# Altium schematic sheet [12] - ANALOG SIGNAL.SchDoc("[12] - ANALOG SIGNAL")
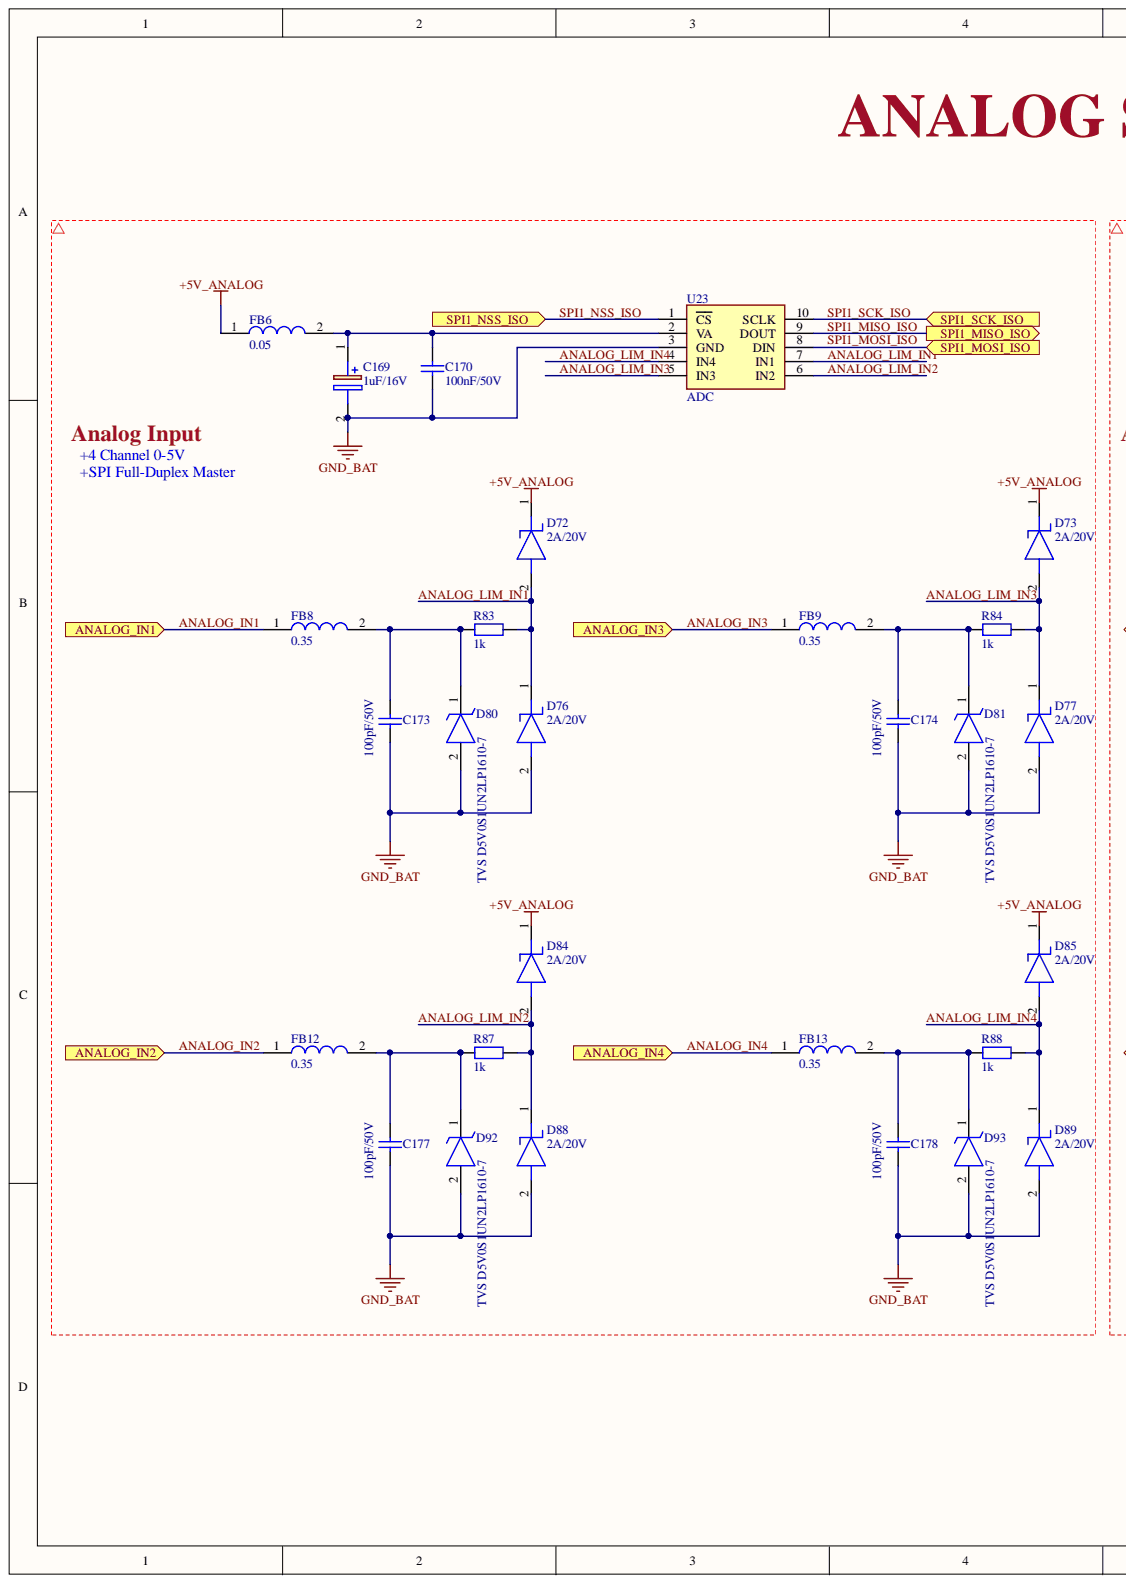
[diagram p0: [12] - ANALOG SIGNAL.SchDoc, left]
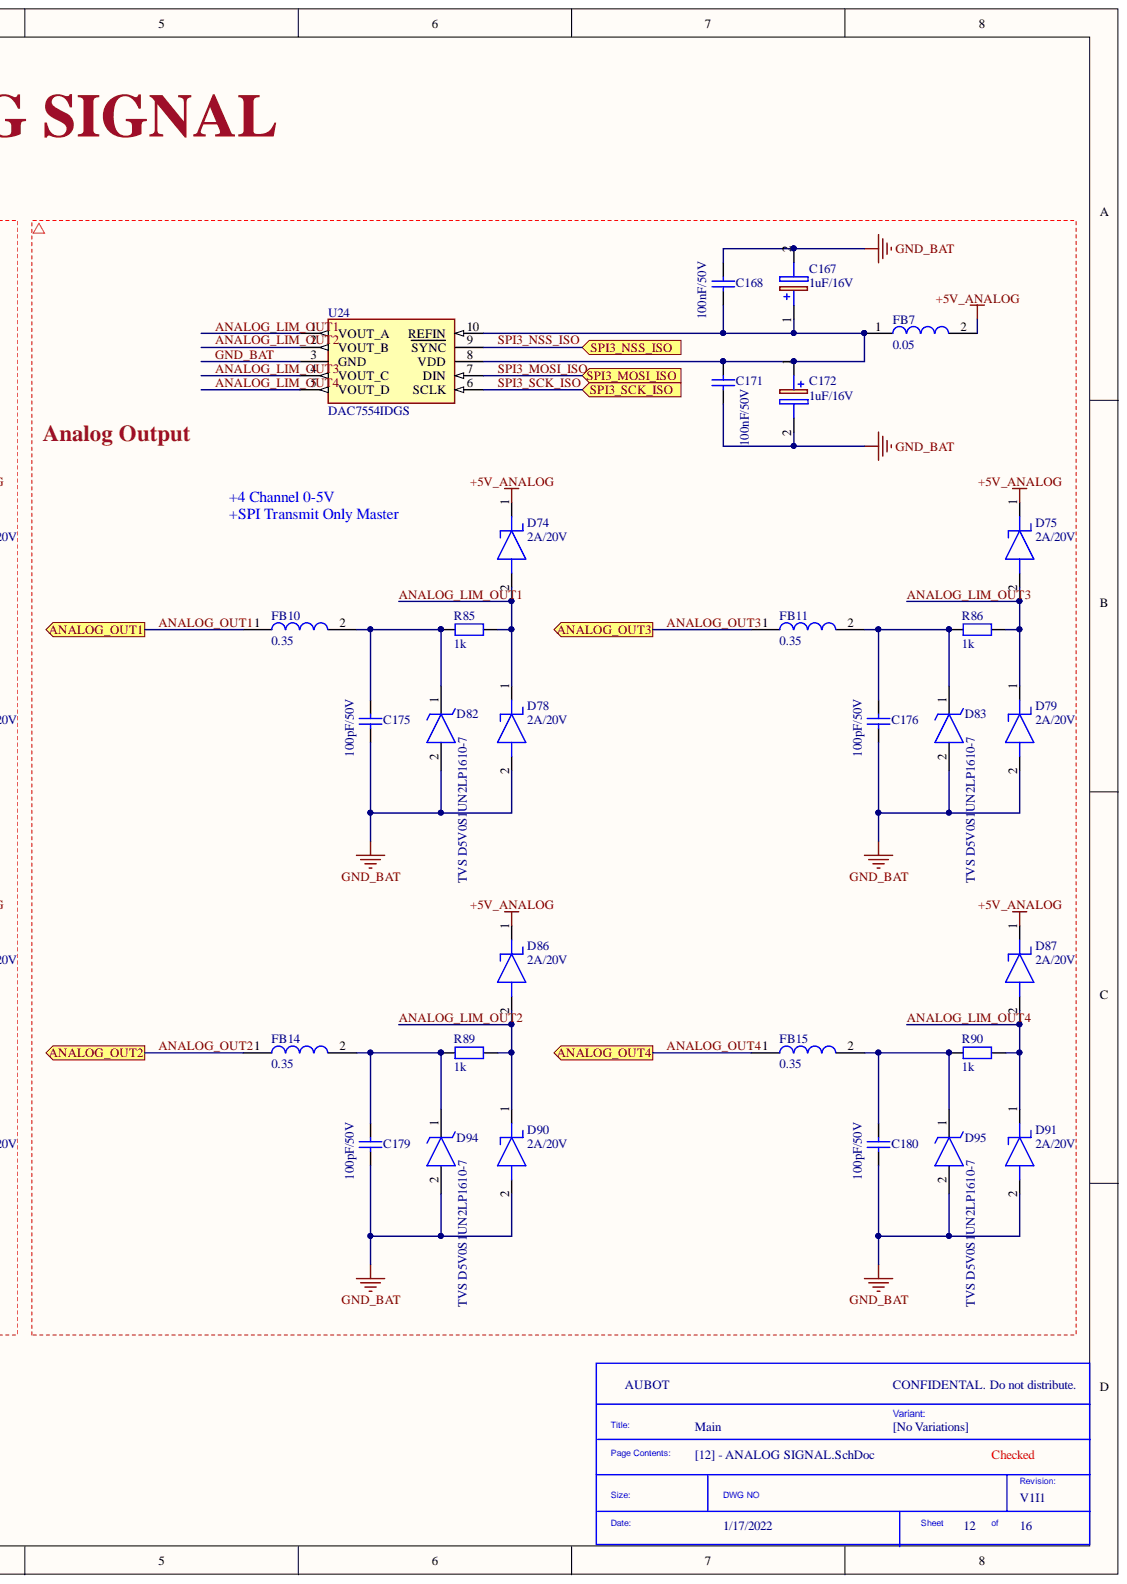
[diagram p1: [12] - ANALOG SIGNAL.SchDoc, right]

## Parts
C167  1uF/16V  [CAP TANT 1206]
C168  100nF/50V  [CAP 0805]
C169  1uF/16V  [CAP TANT 1206]
C170  100nF/50V  [CAP 0805]
C171  100nF/50V  [CAP 0805]
C172  1uF/16V  [CAP TANT 1206]
C173  100pF/50V  [CAP 0805]
C174  100pF/50V  [CAP 0805]
C175  100pF/50V  [CAP 0805]
C176  100pF/50V  [CAP 0805]
C177  100pF/50V  [CAP 0805]
C178  100pF/50V  [CAP 0805]
C179  100pF/50V  [CAP 0805]
C180  100pF/50V  [CAP 0805]
D72  2A/20V  [DIODE SOD-323-2]
D73  2A/20V  [DIODE SOD-323-2]
D74  2A/20V  [DIODE SOD-323-2]
D75  2A/20V  [DIODE SOD-323-2]
D76  2A/20V  [DIODE SOD-323-2]
D77  2A/20V  [DIODE SOD-323-2]
D78  2A/20V  [DIODE SOD-323-2]
D79  2A/20V  [DIODE SOD-323-2]
D80  TVS D5V0S1UN2LP1610-7  Manufacturer Part Number: D5V0S1UN2LP1610-7  [DFN110P158X55-2N]
D81  TVS D5V0S1UN2LP1610-7  Manufacturer Part Number: D5V0S1UN2LP1610-7  [DFN110P158X55-2N]
D82  TVS D5V0S1UN2LP1610-7  Manufacturer Part Number: D5V0S1UN2LP1610-7  [DFN110P158X55-2N]
D83  TVS D5V0S1UN2LP1610-7  Manufacturer Part Number: D5V0S1UN2LP1610-7  [DFN110P158X55-2N]
D84  2A/20V  [DIODE SOD-323-2]
D85  2A/20V  [DIODE SOD-323-2]
D86  2A/20V  [DIODE SOD-323-2]
D87  2A/20V  [DIODE SOD-323-2]
D88  2A/20V  [DIODE SOD-323-2]
D89  2A/20V  [DIODE SOD-323-2]
D90  2A/20V  [DIODE SOD-323-2]
D91  2A/20V  [DIODE SOD-323-2]
D92  TVS D5V0S1UN2LP1610-7  Manufacturer Part Number: D5V0S1UN2LP1610-7  [DFN110P158X55-2N]
D93  TVS D5V0S1UN2LP1610-7  Manufacturer Part Number: D5V0S1UN2LP1610-7  [DFN110P158X55-2N]
D94  TVS D5V0S1UN2LP1610-7  Manufacturer Part Number: D5V0S1UN2LP1610-7  [DFN110P158X55-2N]
D95  TVS D5V0S1UN2LP1610-7  Manufacturer Part Number: D5V0S1UN2LP1610-7  [DFN110P158X55-2N]
FB6  0.05  [RES 0603]
FB7  0.05  [RES 0603]
FB8  0.35  [RES 0603]
FB9  0.35  [RES 0603]
FB10  0.35  [RES 0603]
FB11  0.35  [RES 0603]
FB12  0.35  [RES 0603]
FB13  0.35  [RES 0603]
FB14  0.35  [RES 0603]
FB15  0.35  [RES 0603]
R83  1k  [RES 0805]
R84  1k  [RES 0805]
R85  1k  [RES 0805]
R86  1k  [RES 0805]
R87  1k  [RES 0805]
R88  1k  [RES 0805]
R89  1k  [RES 0805]
R90  1k  [RES 0805]
U23  ADC  [MSOP-10]
U24  DAC7554IDGS  [MSOP-10]

## Ports
ANALOG_IN1, ANALOG_IN2, ANALOG_IN3, ANALOG_IN4, ANALOG_OUT1, ANALOG_OUT2, ANALOG_OUT3, ANALOG_OUT4, SPI1_MISO_ISO, SPI1_MOSI_ISO, SPI1_NSS_ISO, SPI1_SCK_ISO, SPI3_MOSI_ISO, SPI3_NSS_ISO, SPI3_SCK_ISO

## Nets
+5V_ANALOG: D72.1 D73.1 D74.1 D75.1 D84.1 D85.1 D86.1 D87.1 FB6.1 FB7.2
ANALOG_IN1: FB8.1 ; port ANALOG_IN1
ANALOG_IN2: FB12.1 ; port ANALOG_IN2
ANALOG_IN3: FB9.1 ; port ANALOG_IN3
ANALOG_IN4: FB13.1 ; port ANALOG_IN4
ANALOG_LIM_IN1: D72.2 D76.1 R83.2 U23.7(IN1)
ANALOG_LIM_IN2: D84.2 D88.1 R87.2 U23.6(IN2)
ANALOG_LIM_IN3: D73.2 D77.1 R84.2 U23.5(IN3)
ANALOG_LIM_IN4: D85.2 D89.1 R88.2 U23.4(IN4)
ANALOG_LIM_OUT1: D74.2 D78.1 R85.2 U24.1(VOUT_A)
ANALOG_LIM_OUT2: D86.2 D90.1 R89.2 U24.2(VOUT_B)
ANALOG_LIM_OUT3: D75.2 D79.1 R86.2 U24.4(VOUT_C)
ANALOG_LIM_OUT4: D87.2 D91.1 R90.2 U24.5(VOUT_D)
ANALOG_OUT1: FB10.1 ; port ANALOG_OUT1
ANALOG_OUT2: FB14.1 ; port ANALOG_OUT2
ANALOG_OUT3: FB11.1 ; port ANALOG_OUT3
ANALOG_OUT4: FB15.1 ; port ANALOG_OUT4
GND_BAT: C167.2 C168.2 C169.2 C170.1 C171.2 C172.2 C173.2 C174.2 C175.2 C176.2 C177.2 C178.2 C179.2 C180.2 D76.2 D77.2 D78.2 D79.2 D80.2 D81.2 D82.2 D83.2 D88.2 D89.2 D90.2 D91.2 D92.2 D93.2 D94.2 D95.2 U23.3(GND) U24.3(GND)
SPI1_MISO_ISO: U23.9(DOUT) ; port SPI1_MISO_ISO
SPI1_MOSI_ISO: U23.8(DIN) ; port SPI1_MOSI_ISO
SPI1_NSS_ISO: U23.1(CS) ; port SPI1_NSS_ISO
SPI1_SCK_ISO: U23.10(SCLK) ; port SPI1_SCK_ISO
SPI3_MOSI_ISO: U24.7(DIN) ; port SPI3_MOSI_ISO
SPI3_NSS_ISO: U24.9(SYNC) ; port SPI3_NSS_ISO
SPI3_SCK_ISO: U24.6(SCLK) ; port SPI3_SCK_ISO
NetC167_1: C167.1 C168.1 C171.1 C172.1 FB7.1 U24.8(VDD) U24.10(REFIN)
NetC169_1: C169.1 C170.2 FB6.2 U23.2(VA)
NetC173_1: C173.1 D80.1 FB8.2 R83.1
NetC174_1: C174.1 D81.1 FB9.2 R84.1
NetC175_1: C175.1 D82.1 FB10.2 R85.1
NetC176_1: C176.1 D83.1 FB11.2 R86.1
NetC177_1: C177.1 D92.1 FB12.2 R87.1
NetC178_1: C178.1 D93.1 FB13.2 R88.1
NetC179_1: C179.1 D94.1 FB14.2 R89.1
NetC180_1: C180.1 D95.1 FB15.2 R90.1

## Library data of the parts
C167 C169 C172 = Cap Tant 1206 1uF/16V: Cap Tant Solid 1uF 16V A CASE 10%( 3.2 X 1.6 X 1.6mm) Inward L SMD 3216-18 11 Ohm 125C T/R; Manufacturer Part Number: TAJA105K016RNJ; Manufacturer: Kyocera AVX; Tolerance: 20%; Polarity: Polar; Leakage Current: 500nA; ESR (Equivalent Series Resistance): 11R; Dissipation Factor: 4%; Dielectric Material: Tantalum; Width: 1.6mm; Weight: 0.000571oz; Voltage Rating (DC): 16V; Voltage Rating: 16V; Voltage: 16V; Termination: SMD/SMT; Supplier Part Number 1: 478-1649-1-ND; Supplier 1: Digi-Key; Stock 1: 10000; Series: TAJ; RoHS Compliant: Yes; RoHS: Yes; REACH SVHC: No SVHC; Radiation Hardening: No; Pricing 1: 1 = 0.37000, 10 = 0.25500, 50 = 0.17880, 100 = 0.15320, 500 = 0.11488, 1000 = 0.09701 (USD); Packaging: Tape and Reel; Package Quantity: 1; Number of Pins: 2; Mount: Surface Mount,PCB; Min Operating Temperature: -55°C; Max Operating Temperature: 125°C; Length: 3.2mm; Lead Pitch: 1.1mm; Lead Free: Lead Free; Height - Seated (Max): 0.071inch; Height: 1.6mm; Features: General Purpose; Depth: 1.6mm; ComponentLink9URL: https://datasheet.ciiva.com/7832/1680476-7832431.pdf?src-supplier=Element14; ComponentLink9Description: https://datasheet.ciiva.com/7832/1680476-7832431.pdf?src-supplier=Element14; ComponentLink8URL: https://datasheet.ciiva.com/7832/1703059-7832429.pdf?src-supplier=Element14; ComponentLink8Description: https://datasheet.ciiva.com/7832/1703059-7832429.pdf?src-supplier=Element14; ComponentLink7URL: https://datasheet.ciiva.com/7832/taj-7832811.pdf?src-supplier=Element14; ComponentLink7Description: https://datasheet.ciiva.com/7832/taj-7832811.pdf?src-supplier=Element14; ComponentLink6URL: https://datasheet.ciiva.com/18710/taj-eng-18710647.pdf?src-supplier=Distrelec; ComponentLink6Description: https://datasheet.ciiva.com/18710/taj-eng-18710647.pdf?src-supplier=Distrelec; ComponentLink5URL: https://datasheet.ciiva.com/263/taj-263409.pdf?src-supplier=Digi-Key; ComponentLink5Description: https://datasheet.ciiva.com/263/taj-263409.pdf?src-supplier=Digi-Key; ComponentLink4URL: https://datasheet.ciiva.com/7832/1703059-7832430.pdf?src-supplier=Element14; ComponentLink4Description: https://datasheet.ciiva.com/7832/1703059-7832430.pdf?src-supplier=Element14; ComponentLink3URL: https://datasheet.ciiva.com/7832/taj-7832810.pdf?src-supplier=Element14; ComponentLink3Description: https://datasheet.ciiva.com/7832/taj-7832810.pdf?src-supplier=Element14; ComponentLink2URL: https://datasheet.ciiva.com/263/taj-263411.pdf?src-supplier=Digi-Key; ComponentLink2Description: https://datasheet.ciiva.com/263/taj-263411.pdf?src-supplier=Digi-Key; ComponentLink1URL: https://datasheet.ciiva.com/263/taj-263410.pdf?src-supplier=Digi-Key; ComponentLink1Description: https://datasheet.ciiva.com/263/taj-263410.pdf?src-supplier=Digi-Key; Component Kind: Standard; Case Code (Metric): 3216; Case Code (Imperial): 1206; Case/Package: 1206; Capacitance: 1uF; Library Name: Hieu_Lib.IntLib
C168 C170 C171 = Cap 0805 100nF/50V: Ceramic capacitor SMD-0805 100nF /50V X7R# K +-10%, Samsung, RoHS; Manufacturer Part Number: CL21B104KBCNNNC; Voltage Rating (DC): 50V; Tolerance: 10%; Dielectric: X7R; Width: 0.049inch; Voltage Rating: 50V; Voltage: 50V; Thickness: 0.037inch; Supplier Part Number 1: C1711; Supplier 1: LCSC; Stock 1: 447650; Series: CL; RoHS Compliant: Yes; RoHS: Yes; Radiation Hardening: No; Pricing 1: 50 = 0.04090, 500 = 0.03130, 1500 = 0.02890, 5000 = 0.02580, 25000 = 0.02430, 50000 = 0.02410, 50 = 0.03950, 500 = 0.03020, 1500 = 0.02790, 5000 = 0.02490, 25000 = 0.02350, 50000 = 0.02330, 50 = 0.20680, 500 = 0.15820, 1500 = 0.14600, 5000 = 0.13020, 25000 = 0.12290, 50000 = 0.12170, 50 = 0.19640, 500 = 0.15020, 1500 = 0.13860, 5000 = 0.12360, 25000 = 0.11670, 50000 = 0.11550, 50 = 0.02640, 500 = 0.02020, 1500 = 0.01860, 5000 = 0.01660, 25000 = 0.01570, 50000 = 0.01550, 50 = 0.02300, 500 = 0.01760, 1500 = 0.01620, 5000 = 0.01440, 25000 = 0.01360, 50000 = 0.01350, 50 = 0.24740, 500 = 0.18920, 1500 = 0.17470, 5000 = 0.15570, 25000 = 0.14700, 50000 = 0.14560, 50 = 3.45220, 500 = 2.64000, 1500 = 2.43700, 5000 = 2.17300, 25000 = 2.05110, 50000 = 2.03080, 50 = 0.13070, 500 = 0.09990, 1500 = 0.09220, 5000 = 0.08230, 25000 = 0.07760, 50000 = 0.07690, 50 = 2.39050, 500 = 1.82810, 1500 = 1.68750, 5000 = 1.50470, 25000 = 1.42030, 50000 = 1.40620, 50 = 0.26740, 500 = 0.20450, 1500 = 0.18870, 5000 = 0.16830, 25000 = 0.15890, 50000 = 0.15730, 50 = 0.04230, 500 = 0.03230, 1500 = 0.02990, 5000 = 0.02660, 25000 = 0.02510, 50000 = 0.02490, 50 = 0.03188, 500 = 0.02438, 1500 = 0.02251, 5000 = 0.02007, 25000 = 0.01894, 50000 = 0.01875 (AUD); Packaging: Tape and Reel; Mount: Surface Mount; Min Operating Temperature: -55°C; Max Operating Temperature: 125°C; Material: Ceramic; Manufacturer: Samsung; Length: 2mm; Lead Free: Lead Free; Height: 0.85mm; Depth: 1.25mm; Contact Plating: Tin; Construction: Flat; ComponentLink2URL: https://datasheet.ciiva.com/29199/454152-da-01-en-vs-kondensator-0805-29199814.pdf?src-supplier=Conrad; ComponentLink2Description: https://datasheet.ciiva.com/29199/454152-da-01-en-vs-kondensator-0805-29199814.pdf?src-supplier=Conrad; ComponentLink1URL: https://datasheet.ciiva.com/25710/cl21b104kbcnnnc-25710182.pdf?src-supplier=Digi-Key; ComponentLink1Description: https://datasheet.ciiva.com/25710/cl21b104kbcnnnc-25710182.pdf?src-supplier=Digi-Key; Component Kind: Standard; Case Code (Metric): 2012; Case Code (Imperial): 0805; Case/Package: 0805; Capacitance: 100nF; Library Name: Hieu_Lib.IntLib
C173-C180 = Cap 0805 100pF/50V: 0805 100 pF 50 V ±10 % Tolerance X7R SMT Multilayer Ceramic Capacitor; Manufacturer Part Number: CC0805KRX7R9BB101; Manufacturer: Yageo; Voltage Rating (DC): 50V; Tolerance: 10%; Capacitance: 100pF; Width: 0.049inch; Weight: 0.000194oz; Voltage Rating: 50V; Thickness: 0.028inch; Termination: SMD/SMT; Supplier Part Number 1: 311-3603-1-ND; Supplier 1: Digi-Key; Stock 1: 33076; Series: CC; RoHS Compliant: Yes; RoHS: Yes; Resistor Type: Ceramic; Radiation Hardening: No; Pricing 1: 1 = 0.12000, 10 = 0.08500, 50 = 0.04840, 100 = 0.04010, 500 = 0.02810, 1000 = 0.02326 (USD); Packaging: Tape and Reel; Mount: Surface Mount; Min Operating Temperature: -55°C; Max Operating Temperature: 125°C; Length: 2mm; Lead Free: Lead Free; Halogen Free: Halogen Free; Dielectric: X7R; Depth: 1.25mm; Construction: Flat; ComponentLink2URL: https://datasheet.ciiva.com/17436/upy-gphc-x7r-6-3v-to-50v-8-17436651.pdf?src-supplier=Avnet+Europe; ComponentLink2Description: https://datasheet.ciiva.com/17436/upy-gphc-x7r-6-3v-to-50v-8-17436651.pdf?src-supplier=Avnet+Europe; ComponentLink1URL: https://datasheet.ciiva.com/11465/upy-gphc-x7r-6-3v-to-50v-8-11465306.pdf?src-supplier=Avnet; ComponentLink1Description: https://datasheet.ciiva.com/11465/upy-gphc-x7r-6-3v-to-50v-8-11465306.pdf?src-supplier=Avnet; Component Kind: Standard; Case Code (Metric): 2012; Case Code (Imperial): 0805; Case/Package: 0805; Library Name: Hieu_Lib.IntLib
D72-D79 D84-D91 = Diode Schottky 2A: SDM2U20SD3 Series 20 V 2 A Surface Mount Schottky Barrier Rectifier - SOD-323; Manufacturer Part Number: SDM2U20SD3-7; Manufacturer: Diodes; Max Reverse Voltage (DC): 20V; Average Rectified Current: 2A; Supplier Part Number 1: 621-SDM2U20SD3-7; Supplier 1: Mouser; Stock 1: 485; RoHS Compliant: Yes; RoHS: Yes; Pricing 1: 1 = 0.46000, 10 = 0.35200, 50 = 0.35200, 100 = 0.20000, 1000 = 0.10200, 10000 = 0.07000 (USD); Packaging: Cut Tape; Mount: Surface Mount; ComponentLink3URL: http://diodes.com/datasheets/SDM2U20SD3.pdf?src-supplier=Avnet; ComponentLink3Description: http://diodes.com/datasheets/SDM2U20SD3.pdf?src-supplier=Avnet; ComponentLink2URL: https://www.diodes.com/assets/Datasheets/SDM2U20SD3.pdf?src-supplier=Digi-Key; ComponentLink2Description: https://www.diodes.com/assets/Datasheets/SDM2U20SD3.pdf?src-supplier=Digi-Key; ComponentLink1URL: http://www.mouser.com/ds/2/115/SDM2U20SD3-537776.pdf?src-supplier=Mouser; ComponentLink1Description: http://www.mouser.com/ds/2/115/SDM2U20SD3-537776.pdf?src-supplier=Mouser; Component Kind: Standard; Library Name: Hieu_Lib.IntLib
D80-D83 D92-D95 = TVS D5V0S1UN2LP1610-7: ESD Suppressors / TVS Diodes Surge Protection PP U-DFN1610-2 T&R 10K; Supplier Part Number 1: 621-D5V0S1UN2LP16107; Supplier 1: Mouser; Stock 1: 48367; RoHS: No; Pricing 1: 1 = 0.42000, 10 = 0.32000, 50 = 0.32000, 100 = 0.19900, 1000 = 0.10500, 10000 = 0.08100 (USD); Manufacturer: Diodes; Component Kind: Standard; Library Name: Hieu_Lib.IntLib
FB6 FB7 = Ferrite Bead 0603 30Ohm 100Mhz: FERRITE BEAD 30 OHM 0603 1LN / Ferrite Beads Multi-Layer 30Ohm 100MHz 1A 0.05Ohm DCR 0603 T/R; Manufacturer Part Number: BLM18PG300SN1D; Manufacturer: Murata; Tolerance: 25%; Shielding: Unshielded; Number of Lines: 1; Material: Steel,Ferrite; Impedance: 30R; DC Resistance-Max: 50mR; Width: 0.8mm; Test Frequency: 100MHz; Termination: SMD/SMT; Supplier Part Number 1: 490-1035-1-ND; Supplier 1: Digi-Key; Stock 1: 1731; Series: BLM18P; RoHS Compliant: Yes; RoHS: Yes; REACH SVHC: No SVHC; Radiation Hardening: No; Pricing 1: 1 = 0.10000, 10 = 0.08300, 25 = 0.07600, 50 = 0.06840, 100 = 0.05010, 250 = 0.03644, 500 = 0.03340, 1000 = 0.02884 (USD); Packaging: Tape and Reel; Package Quantity: 4000; Number of Pins: 2; Number of Circuits: 1; Min Operating Temperature: -55°C; Max Operating Temperature: 125°C; Max DC Current: 1A; Max Current Rating: 1A; Length: 1.6mm; Lead Free: Lead Free; Height: 0.8mm; Frequency: 100MHz; Depth: 0.8mm; DC Resistance (DCR): 50mR; DC Current: 1A; Current Rating: 1A; Core Material: Ferrite; ComponentLink11URL: https://datasheet.ciiva.com/8021/5148-8021486.pdf?src-supplier=Element14; ComponentLink11Description: https://datasheet.ciiva.com/8021/5148-8021486.pdf?src-supplier=Element14; ComponentLink10URL: https://datasheet.ciiva.com/17246/l0110s0100blm18p-17246940.pdf?src-supplier=Avnet+Europe; ComponentLink10Description: https://datasheet.ciiva.com/17246/l0110s0100blm18p-17246940.pdf?src-supplier=Avnet+Europe; ComponentLink9URL: https://datasheet.ciiva.com/8021/5148-8021484.pdf?src-supplier=Element14; ComponentLink9Description: https://datasheet.ciiva.com/8021/5148-8021484.pdf?src-supplier=Element14; ComponentLink8URL: https://datasheet.ciiva.com/1012/l0110s0100blm18p-1012121.pdf?src-supplier=Digi-Key; ComponentLink8Description: https://datasheet.ciiva.com/1012/l0110s0100blm18p-1012121.pdf?src-supplier=Digi-Key; ComponentLink7URL: https://datasheet.ciiva.com/1012/l0110s0100blm18p-1012120.pdf?src-supplier=Digi-Key; ComponentLink7Description: https://datasheet.ciiva.com/1012/l0110s0100blm18p-1012120.pdf?src-supplier=Digi-Key; ComponentLink6URL: https://datasheet.ciiva.com/16420/l0110s0100blm18p-16420743.pdf?src-supplier=Future+Electronics; ComponentLink6Description: https://datasheet.ciiva.com/16420/l0110s0100blm18p-16420743.pdf?src-supplier=Future+Electronics; ComponentLink5URL: https://datasheet.ciiva.com/1012/l0110s0100blm18p-1012119.pdf?src-supplier=Digi-Key; ComponentLink5Description: https://datasheet.ciiva.com/1012/l0110s0100blm18p-1012119.pdf?src-supplier=Digi-Key; ComponentLink4URL: https://datasheet.ciiva.com/3081/l0110s0100blm18p-3081636.pdf?src-supplier=Avnet; ComponentLink4Description: https://datasheet.ciiva.com/3081/l0110s0100blm18p-3081636.pdf?src-supplier=Avnet; ComponentLink3URL: https://datasheet.ciiva.com/3081/l0110s0100blm18p-3081635.pdf?src-supplier=Avnet; ComponentLink3Description: https://datasheet.ciiva.com/3081/l0110s0100blm18p-3081635.pdf?src-supplier=Avnet; ComponentLink2URL: https://datasheet.ciiva.com/19460/1845048-19460200.pdf?src-supplier=Jameco; ComponentLink2Description: https://datasheet.ciiva.com/19460/1845048-19460200.pdf?src-supplier=Jameco; ComponentLink1URL: https://datasheet.ciiva.com/11800/0900766b81275ca5-11800868.pdf?src-supplier=RSComponents; ComponentLink1Description: https://datasheet.ciiva.com/11800/0900766b81275ca5-11800868.pdf?src-supplier=RSComponents; Component Kind: Standard; Case Code (Metric): 1608; Case Code (Imperial): 0603; Case/Package: 0603; Library Name: Hieu_Lib.IntLib
FB8-FB15 = Ferrite Beads 0603 470 Ohm 100Mhz: Ferrite Beads Multi-Layer 470Ohm 25% 100MHz 500mA 350mOhm DCR 0603 Paper T/R; Manufacturer Part Number: BLM18AG471SN1D; Manufacturer: Murata; Shielding: Unshielded; Number of Lines: 1; Material: Ferrite,Steel; Impedance: 470R; DC Resistance-Max: 350mR; Width: 0.8mm; Tolerance: 25%; Test Frequency: 100MHz; Termination: SMD/SMT; Supplier Part Number 1: 490-1013-1-ND; Supplier 1: Digi-Key; Stock 1: 83235; Series: BLM18A; RoHS Compliant: Yes; RoHS: Yes; REACH SVHC: No SVHC; Radiation Hardening: No; Pricing 1: 1 = 0.10000, 10 = 0.07900, 25 = 0.07200, 50 = 0.06480, 100 = 0.04760, 250 = 0.03460, 500 = 0.03170, 1000 = 0.02738 (USD); Packaging: Tape and Reel; Package Quantity: 1; Number of Pins: 2; Number of Circuits: 1; Min Operating Temperature: -55°C; Military Standard: Not; Max Operating Temperature: 125°C; Max DC Current: 500mA; Max Current Rating: 500mA; Length: 1.6mm; Lead Free: Lead Free; Height: 0.8mm; Frequency: 100MHz; Depth: 0.8mm; DC Resistance (DCR): 350mR; DC Current: 500mA; Current Rating: 500mA; Core Material: Ferrite; ComponentLink10URL: https://datasheet.ciiva.com/14122/blm-series-eng-tds-14122873.pdf?src-supplier=Distrelec; ComponentLink10Description: https://datasheet.ciiva.com/14122/blm-series-eng-tds-14122873.pdf?src-supplier=Distrelec; ComponentLink9URL: https://datasheet.ciiva.com/8510/l0110s0100blm18a-8510056.pdf?src-supplier=Avnet; ComponentLink9Description: https://datasheet.ciiva.com/8510/l0110s0100blm18a-8510056.pdf?src-supplier=Avnet; ComponentLink8URL: https://datasheet.ciiva.com/1012/l0110s0100blm18a-1012037.pdf?src-supplier=Digi-Key; ComponentLink8Description: https://datasheet.ciiva.com/1012/l0110s0100blm18a-1012037.pdf?src-supplier=Digi-Key; ComponentLink7URL: https://datasheet.ciiva.com/1466/356366-1466932.pdf?src-supplier=Element14; ComponentLink7Description: https://datasheet.ciiva.com/1466/356366-1466932.pdf?src-supplier=Element14; ComponentLink6URL: https://datasheet.ciiva.com/1012/l0110s0100blm18a-1012036.pdf?src-supplier=Digi-Key; ComponentLink6Description: https://datasheet.ciiva.com/1012/l0110s0100blm18a-1012036.pdf?src-supplier=Digi-Key; ComponentLink5URL: https://datasheet.ciiva.com/1466/356366-1466931.pdf?src-supplier=Element14; ComponentLink5Description: https://datasheet.ciiva.com/1466/356366-1466931.pdf?src-supplier=Element14; ComponentLink4URL: https://datasheet.ciiva.com/1012/l0110s0100blm18a-1012035.pdf?src-supplier=Digi-Key; ComponentLink4Description: https://datasheet.ciiva.com/1012/l0110s0100blm18a-1012035.pdf?src-supplier=Digi-Key; ComponentLink3URL: https://datasheet.ciiva.com/41449/001172226-da-01-en-drossel-0603-470r-1-6x0-8mm-4000st-41449516.pdf?src-supplier=Conrad; ComponentLink3Description: https://datasheet.ciiva.com/41449/001172226-da-01-en-drossel-0603-470r-1-6x0-8mm-4000st-41449516.pdf?src-supplier=Conrad; ComponentLink2URL: https://datasheet.ciiva.com/29347/442296-da-01-en-chip-drossel-0603-470r-500ma-1-6x0-8mm-29347895.pdf?src-supplier=Conrad; ComponentLink2Description: https://datasheet.ciiva.com/29347/442296-da-01-en-chip-drossel-0603-470r-500ma-1-6x0-8mm-29347895.pdf?src-supplier=Conrad; ComponentLink1URL: https://datasheet.ciiva.com/11800/0900766b811b5866-11800883.pdf?src-supplier=RSComponents; ComponentLink1Description: https://datasheet.ciiva.com/11800/0900766b811b5866-11800883.pdf?src-supplier=RSComponents; Component Kind: Standard; Case Code (Metric): 1608; Case Code (Imperial): 0603; Case/Package: 0603; Library Name: Hieu_Lib.IntLib
R83-R90 = Res 0805 1k Ohm 1%: Res Thick Film 0805 1KOhm 1% 0.125W(1/8W) 100ppm/C Epoxy Pad SMD T/R; Manufacturer Part Number: RC0805FR-071KL; Manufacturer: Yageo; Voltage Rating (DC): 150V; Temperature Coefficient: 100ppm/°C; Resistance: 1kR; Power Rating: 125mW; Width: 0.049inch; Voltage Rating: 150V; Tolerance: 1%; Termination: SMD/SMT; Supplier Part Number 1: 311-1.00KCRCT-ND; Supplier 1: Digi-Key; Stock 1: 2324983; Size Code: 0805; Series: RC; RoHS Compliant: Yes; RoHS: Yes; Resistor Type: Thick Film; Resistance Tolerance: 1%; REACH SVHC: Unknown; Pricing 1: 1 = 0.10000, 10 = 0.04200, 100 = 0.01690, 1000 = 0.00759, 2500 = 0.00659 (USD); Packaging: Tape and Reel; Package Quantity: 1; Number of Terminations: 2; Number of Pins: 2; Min Operating Temperature: -55°C; Military Standard: Not; Max Power Dissipation: 125mW; Max Operating Temperature: 155°C; Length: 0.079inch; Lead Free: Lead Free; Height: 0.024inch; Features: Moisture Resistant; Depth: 1.25mm; Construction: Rectangular; Component Kind: Standard; Case Code (Metric): 2012; Case Code (Imperial): 0805; Case/Package: 0805; Library Name: Hieu_Lib.IntLib
U23 = ADC104S021CIMMX/NOPB: 10-Bit, 200-kSPS, 4-Ch SAR ADC with single-ended inputs and serial interface 10-VSSOP -40 to 85; Manufacturer: TI National Semiconductor; Supply Type: Single; Signal to Noise Ratio (SNR): 61.8dB; Sampling Rate: 200KS/s; Resolution: 10b; Power Consumption: 1.94mW; Polarity: Unipolar; Operating Supply Voltage: 5V; Number of Inputs: 4; Number of Elements: 1; Number of Bits: 10; Min Supply Voltage: 2.7V; Max Supply Voltage: 5.25V; Max Power Dissipation: 8mW; Interface: SPI,Serial; Integral Nonlinearity (INL): -0.4LSB; Full Scale Error: 0.7LSB; Differential Nonlinearity: 0.4LSB; Supplier Part Number 1: 926-A104S021CIMMXNPB; Supplier 1: Mouser; Stock 1: 3031; Series: Automotive, AEC-Q100; RoHS Compliant: Yes; RoHS: Yes; Radiation Hardening: No; Pricing 1: 1 = 3.09000, 10 = 2.78000, 25 = 2.63000, 50 = 2.63000, 100 = 2.28000, 250 = 2.16000, 500 = 1.94000, 1000 = 1.70000, 7000 = 1.69000, 10000 = 1.70000 (USD); Packaging: Tape and Reel; Package Quantity: 3500; Number of Pins: 10; Number of Converters: 1; Number of Channels: 4; Number of Analog Inputs: 4; Number of A/D Converters: 1; Mount: Surface Mount; Min Operating Temperature: -40°C; Max Operating Temperature: 85°C; Manufacturer Part Number: ADC104S021CIMMX/NOPB; Lead Free: Lead Free; Input Type: SINGLE-ENDED; Conversion Rate: 200KS/s; Contact Plating: Tin,Matte; ComponentLink2URL: https://datasheet.ciiva.com/6659/adc104s021-6659898.pdf?src-supplier=Digi-Key; ComponentLink2Description: https://datasheet.ciiva.com/6659/adc104s021-6659898.pdf?src-supplier=Digi-Key; ComponentLink1URL: https://datasheet.ciiva.com/26888/getdatasheetpartid-660655-26888437.pdf?src-supplier=Verical; ComponentLink1Description: https://datasheet.ciiva.com/26888/getdatasheetpartid-660655-26888437.pdf?src-supplier=Verical; Component Kind: Standard; Case/Package: VSSOP; Library Name: Hieu_Lib.SchLib
U24 = DAC7554IDGS: Digital to Analog Converter 4 Channel Resistor-String 12-Bit 10-Pin MSOP Tube; Manufacturer: Texas Instruments; Supply Type: Single; Settling Time: 5us; Sampling Rate: 1MS/s; Resolution: 12b; Power Consumption: 3.5mW; Polarity: Unipolar; Output Type: Voltage; Operating Supply Voltage: 5V; Number of DAC Channels: 4; Number of Bits: 12; Nominal Supply Current: 700uA; Min Supply Voltage: 2.7V; Max Supply Voltage: 5.5V; Interface: SPI; Integral Nonlinearity (INL): 1LSB; Differential Nonlinearity: 0.5LSB; Converter Type: GENERAL PURPOSE; Width: 3mm; Weight: 0.000663oz; Supplier Part Number 1: 296-17551-5-ND; Supplier 1: Digi-Key; Stock 1: 0; Series: DAC7554; RoHS Compliant: Yes; RoHS: Yes; REACH SVHC: No SVHC; Radiation Hardening: No; Pricing 1: 1 = 12.02000, 10 = 11.04700, 25 = 10.58960, 100 = 9.45000 (USD); Packaging: Rail/Tube; Package Quantity: 80; Output Polarity: Unipolar; Number of Pins: 10; Number of Converters: 4; Number of Channels: 4; Min Operating Temperature: -40°C; Max Operating Temperature: 105°C; Manufacturer Part Number: DAC7554IDGS; Length: 3mm; Lead Free: Lead Free; Height: 1.02mm; ComponentLink9URL: https://datasheet.ciiva.com/48711/001047560-da-01-en-ic-dac-qua-w-sp-dac7554idgs-vssop-10-tid-48711559.pdf?src-supplier=Conrad; ComponentLink9Description: https://datasheet.ciiva.com/48711/001047560-da-01-en-ic-dac-qua-w-sp-dac7554idgs-vssop-10-tid-48711559.pdf?src-supplier=Conrad; ComponentLink8URL: https://datasheet.ciiva.com/25984/dac7554-25984223.pdf?src-supplier=Avnet+Europe; ComponentLink8Description: https://datasheet.ciiva.com/25984/dac7554-25984223.pdf?src-supplier=Avnet+Europe; ComponentLink7URL: https://datasheet.ciiva.com/3040/dac7554-3040477.pdf?src-supplier=Avnet; ComponentLink7Description: https://datasheet.ciiva.com/3040/dac7554-3040477.pdf?src-supplier=Avnet; ComponentLink6URL: https://datasheet.ciiva.com/1364/dac7554-1364075.pdf?src-supplier=Digi-Key; ComponentLink6Description: https://datasheet.ciiva.com/1364/dac7554-1364075.pdf?src-supplier=Digi-Key; ComponentLink5URL: https://datasheet.ciiva.com/15147/getdatasheetpartid-234489-15147002.pdf?src-supplier=Verical; ComponentLink5Description: https://datasheet.ciiva.com/15147/getdatasheetpartid-234489-15147002.pdf?src-supplier=Verical; ComponentLink4URL: https://datasheet.ciiva.com/26804/dac7554idgs-26804306.pdf?src-supplier=IIIC; ComponentLink4Description: https://datasheet.ciiva.com/26804/dac7554idgs-26804306.pdf?src-supplier=IIIC; ComponentLink3URL: https://datasheet.ciiva.com/1494/1771403-1494462.pdf?src-supplier=Element14; ComponentLink3Description: https://datasheet.ciiva.com/1494/1771403-1494462.pdf?src-supplier=Element14; ComponentLink2URL: https://datasheet.ciiva.com/27052/1337641-27052020.pdf?src-supplier=Jameco; ComponentLink2Description: https://datasheet.ciiva.com/27052/1337641-27052020.pdf?src-supplier=Jameco; ComponentLink1URL: https://datasheet.ciiva.com/1597/dac7554-1597362.pdf?src-supplier=Newark; ComponentLink1Description: https://datasheet.ciiva.com/1597/dac7554-1597362.pdf?src-supplier=Newark; Component Kind: Standard; Case/Package: VSSOP; Library Name: Hieu_Lib.IntLib
